# Altium schematic sheet 02 Power.SchDoc
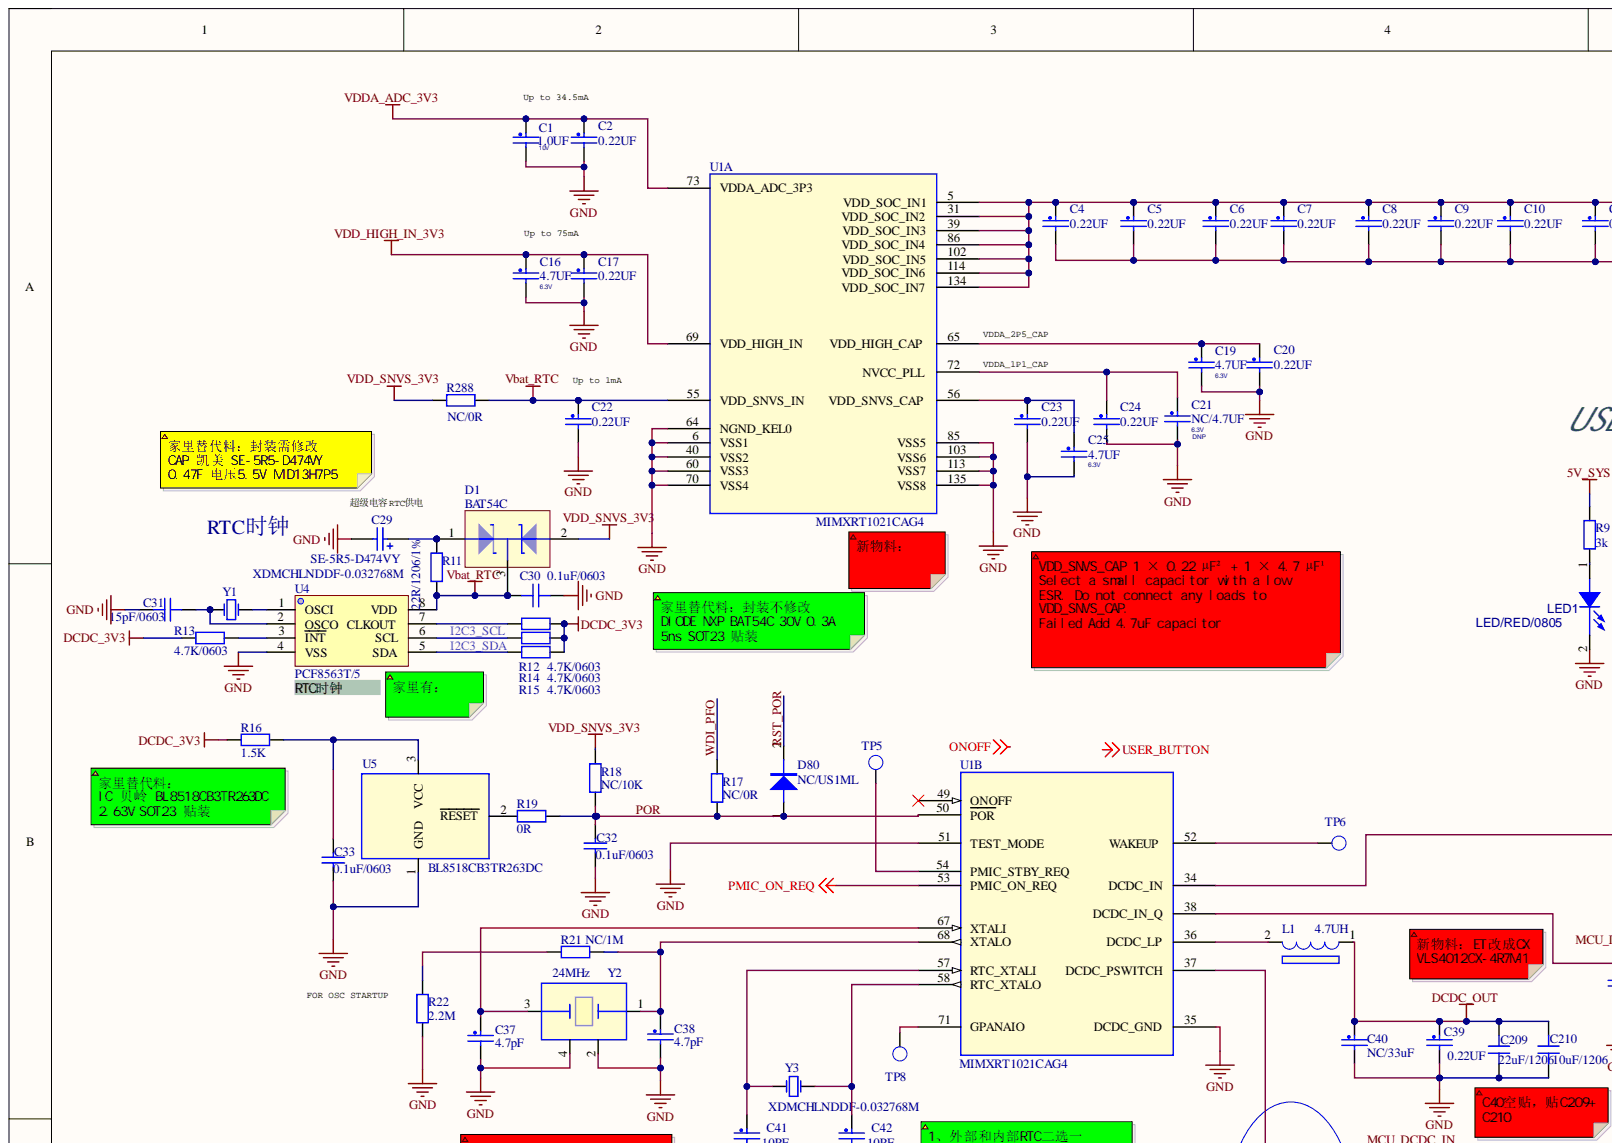
[diagram p0: 02 Power.SchDoc, top left]
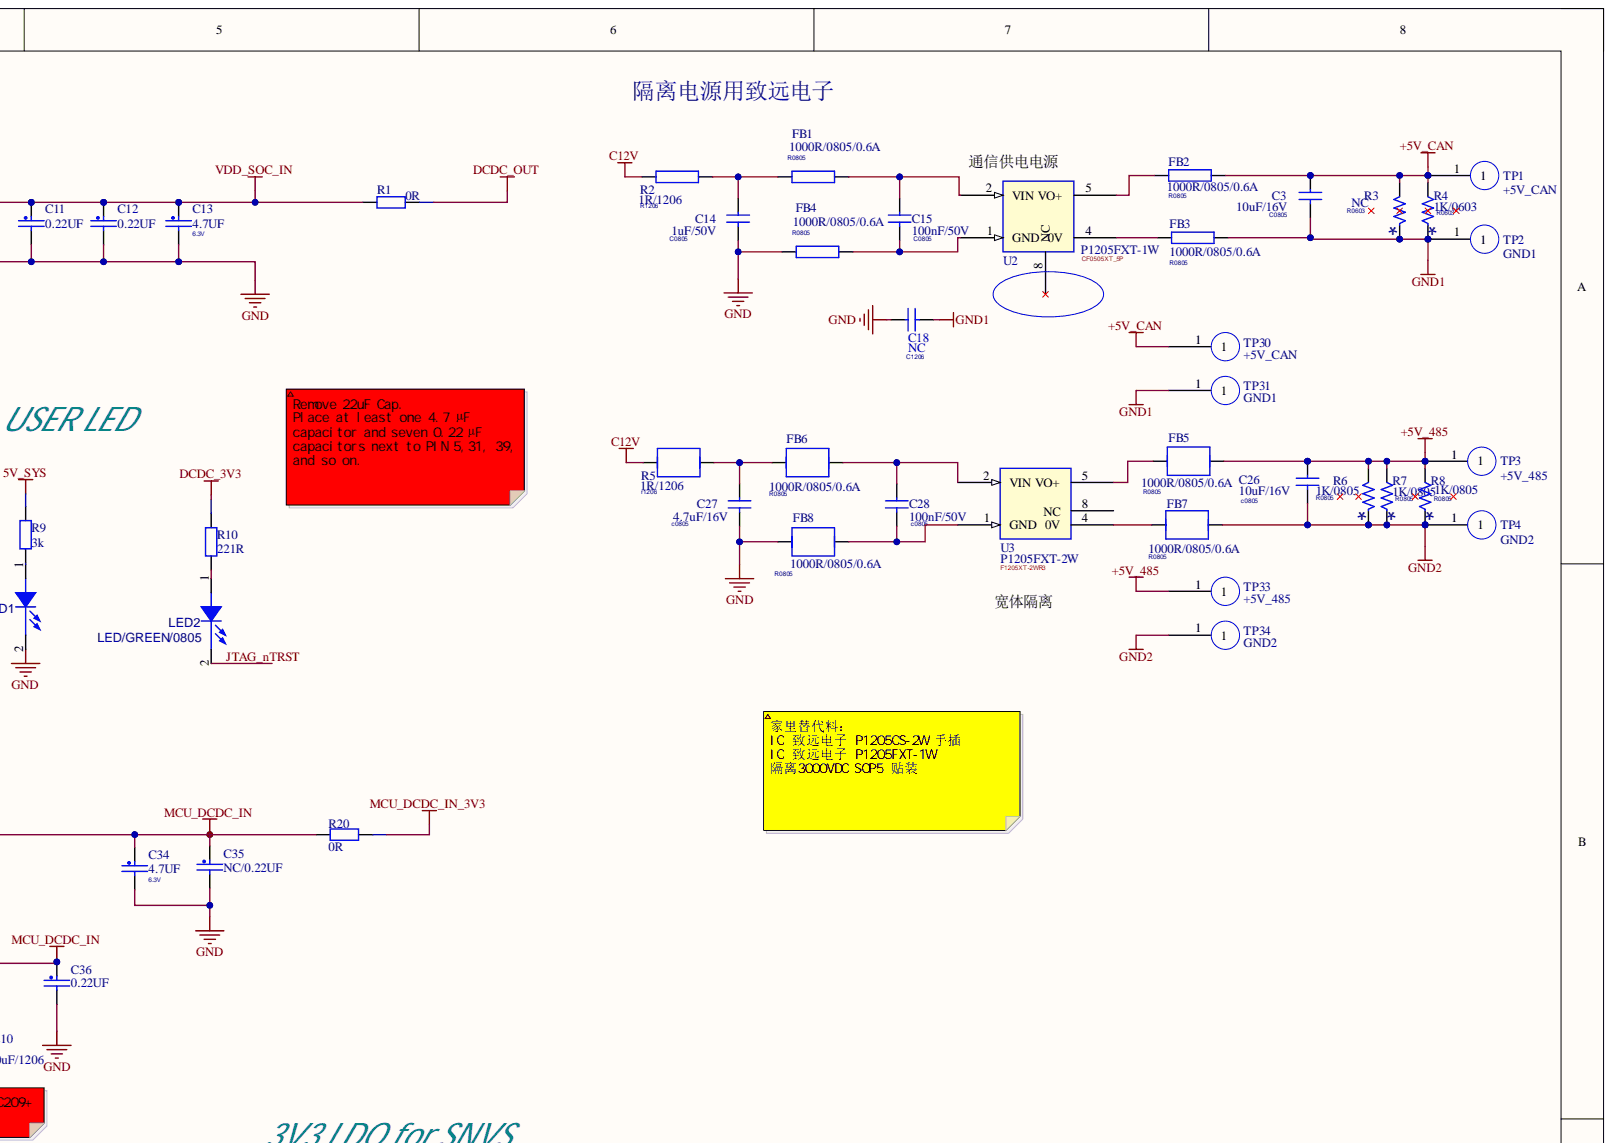
[diagram p1: 02 Power.SchDoc, top right]
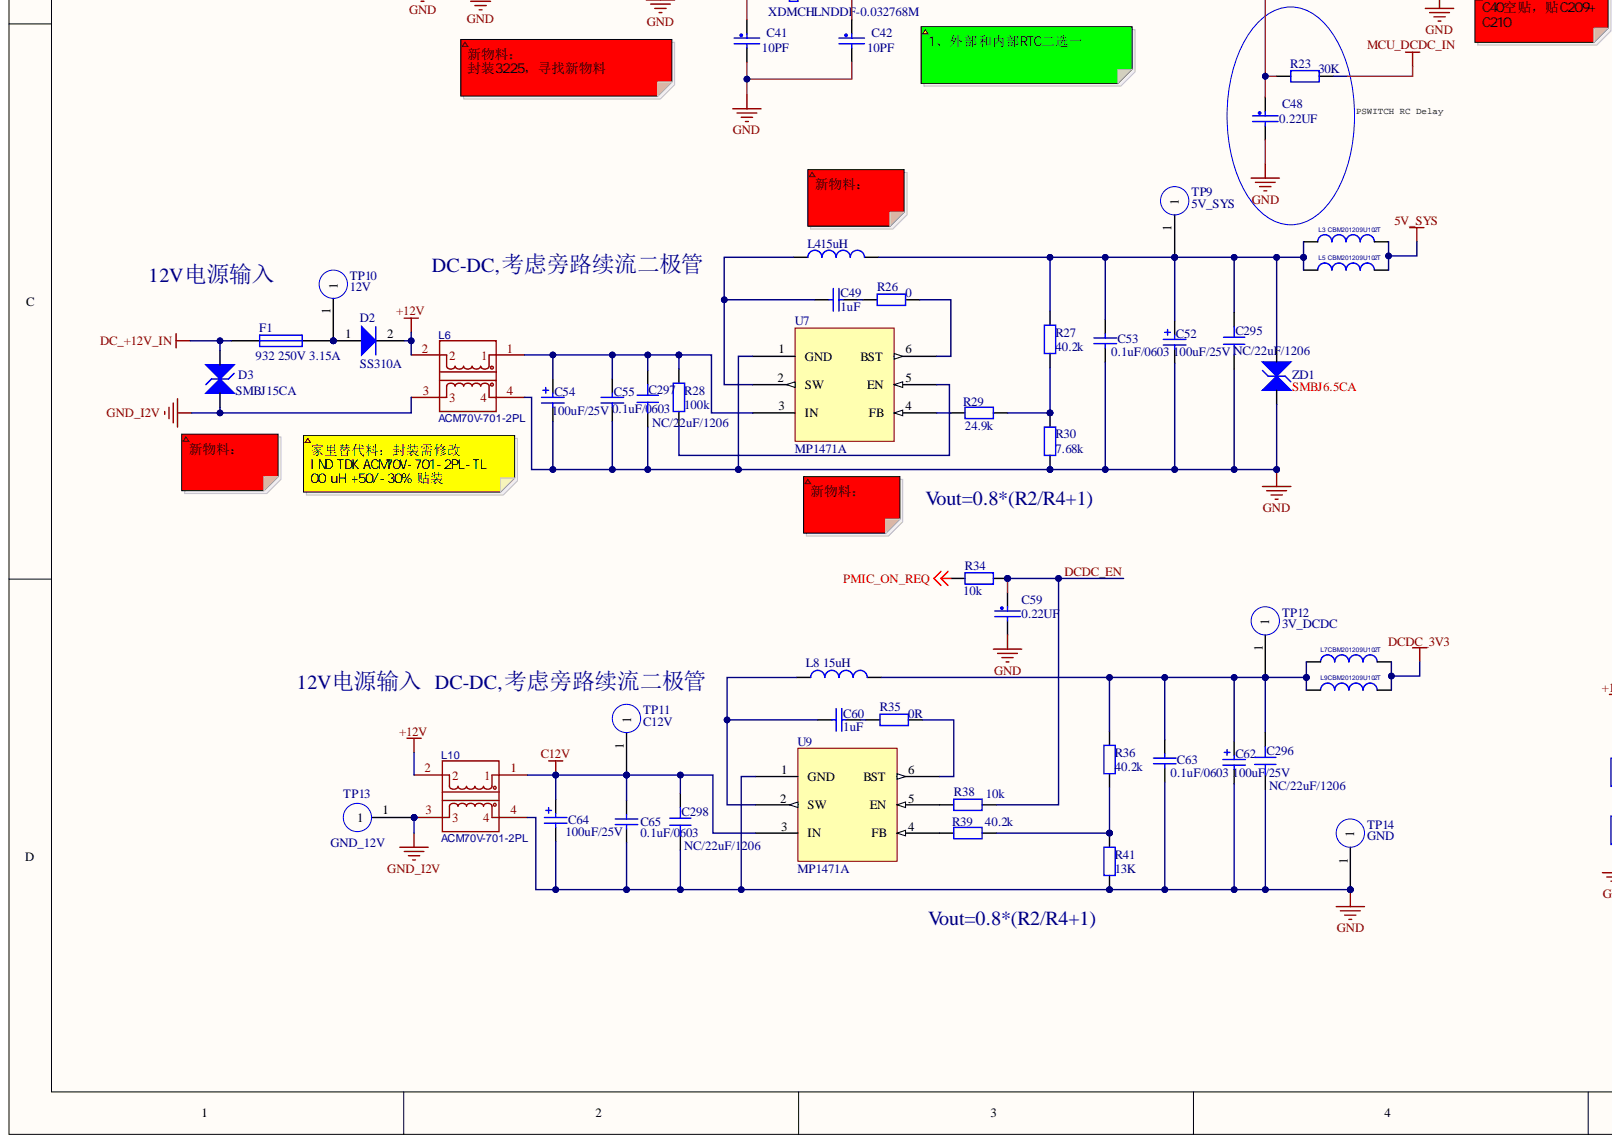
[diagram p2: 02 Power.SchDoc, bottom left]
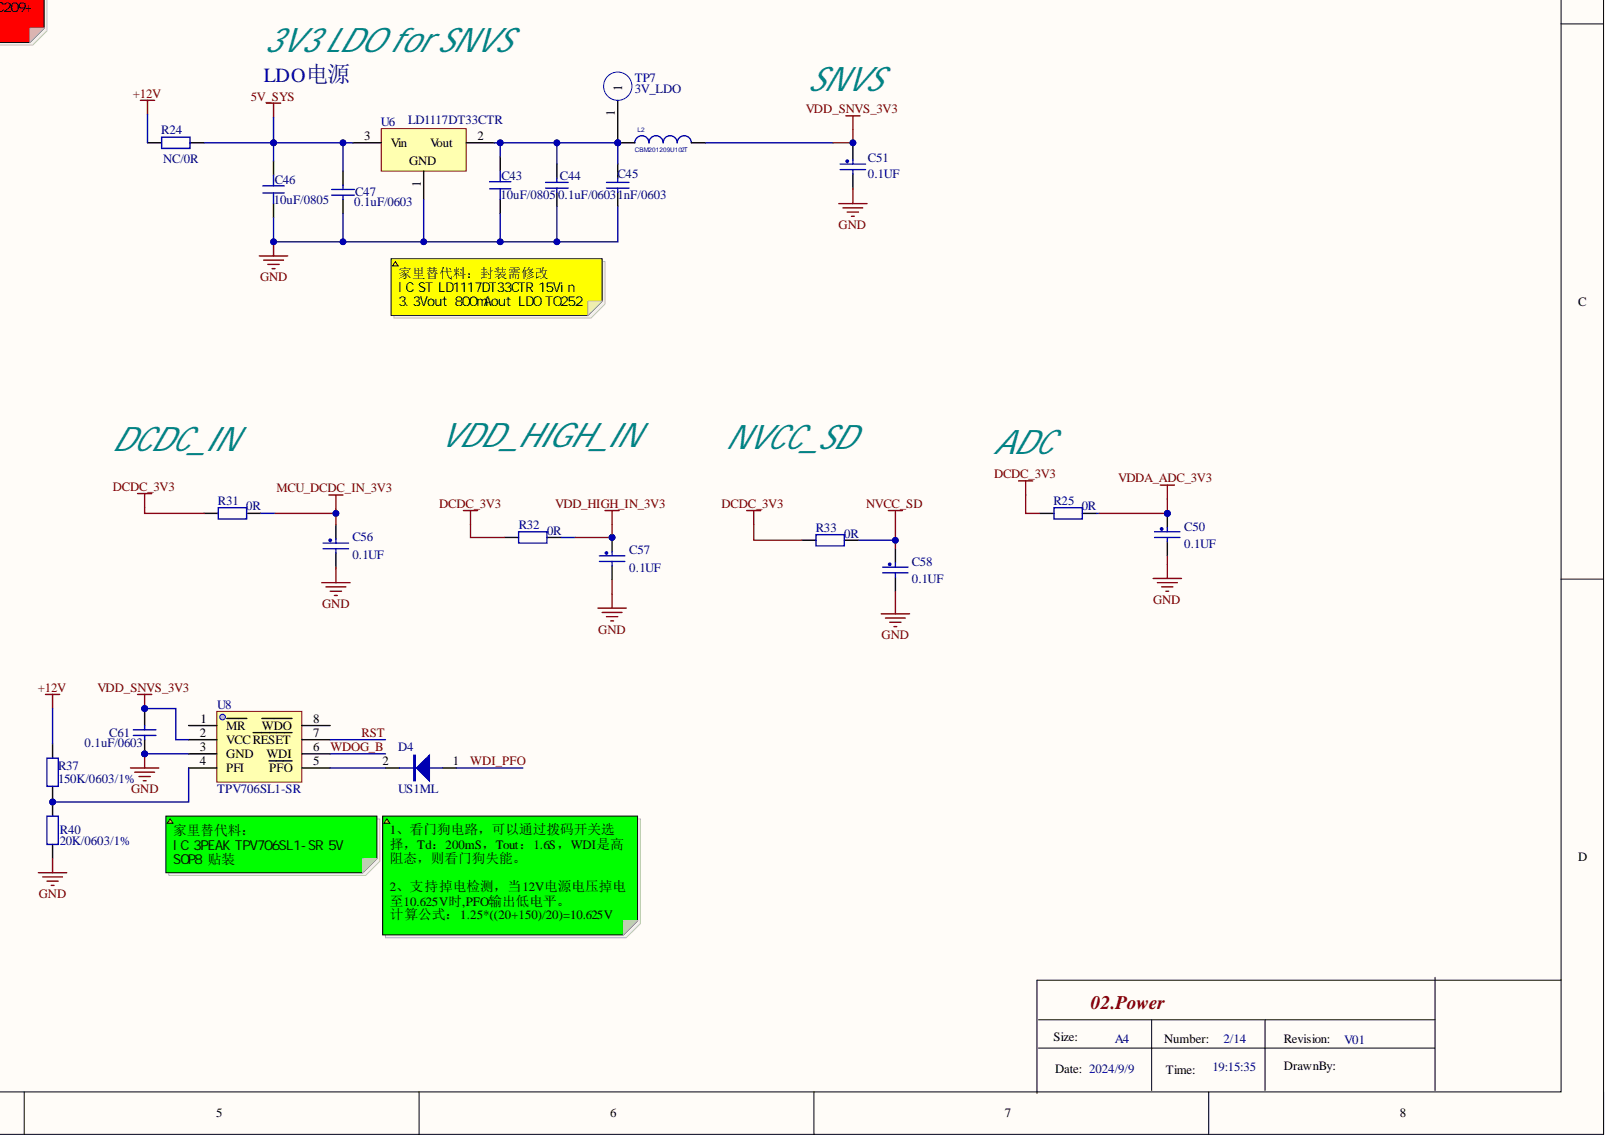
[diagram p3: 02 Power.SchDoc, bottom right]

## Parts
C1  1.0UF  VOLTAGE: 10V  [C0603]
C2  0.22UF  [C0603]
C3  10uF/16V  [C0805]
C4  0.22UF  [C0603]
C5  0.22UF  [C0603]
C6  0.22UF  [C0603]
C7  0.22UF  [C0603]
C8  0.22UF  [C0603]
C9  0.22UF  [C0603]
C10  0.22UF  [C0603]
C11  0.22UF  [C0603]
C12  0.22UF  [C0603]
C13  4.7UF  VOLTAGE: 6.3V  [C0805]
C14  1uF/50V  [C0805]
C15  100nF/50V  [C0805]
C16  4.7UF  VOLTAGE: 6.3V  [C0805]
C17  0.22UF  [C0603]
C18  NC  [C1206]
C19  4.7UF  VOLTAGE: 6.3V  [C0805]
C20  0.22UF  [C0603]
C21  NC/4.7UF  ASSY_OPT: DNP  VOLTAGE: 6.3V  [C0805]
C22  0.22UF  [C0603]
C23  0.22UF  [C0603]
C24  0.22UF  [C0603]
C25  4.7UF  VOLTAGE: 6.3V  [C0805]
C26  10uF/16V  [c0805]
C27  4.7uF/16V  [c0805]
C28  100nF/50V  [c0805]
C29  SE-5R5-D474VY  [HT-5R5-Z474VYL06]
C30  0.1uF/0603  [C0603]
C31  15pF/0603  [C0603]
C32  0.1uF/0603  [C0603]
C33  0.1uF/0603  [C0603]
C34  4.7UF  VOLTAGE: 6.3V  [C0805]
C35  NC/0.22UF  [C0603]
C36  0.22UF  [C0603]
C37  4.7pF  [C0603]
C38  4.7pF  [C0603]
C39  0.22UF  [C0603]
C40  NC/33uF  [C1210]
C41  10PF  [C0603]
C42  10PF  [C0603]
C43  10uF/0805  [C1206]
C44  0.1uF/0603  [C0603]
C45  1nF/0603  [C0603]
C46  10uF/0805  [C1206]
C47  0.1uF/0603  [C0603]
C48  0.22UF  [C0603]
C49  1uF  [C0603]
C50  0.1UF  [C0603]
C51  0.1UF  [C0603]
C52  value 100uF/25V  [CAP D6.3 F2.5]  (hidden comment: 100uF/16V)
C53  0.1uF/0603  [C0603]
C54  value 100uF/25V  [CAP D6.3 F2.5]  (hidden comment: 100uF/16V)
C55  0.1uF/0603  [C0603]
C56  0.1UF  [C0603]
C57  0.1UF  [C0603]
C58  0.1UF  [C0603]
C59  0.22UF  [C0603]
C60  1uF  [C0603]
C61  0.1uF/0603  [C0603]
C62  value 100uF/25V  [CAP D6.3 F2.5]  (hidden comment: 100uF/16V)
C63  0.1uF/0603  [C0603]
C64  value 100uF/25V  [CAP D6.3 F2.5]  (hidden comment: 100uF/16V)
C65  0.1uF/0603  [C0603]
C209  22uF/1206  [C1206]
C210  10uF/1206  [C1206]
C295  NC/22uF/1206  [C1206]
C296  NC/22uF/1206  [C1206]
C297  NC/22uF/1206  [C1206]
C298  NC/22uF/1206  [C1206]
D1  BAT54C  [SOT-23]
D2  SS310A  [SMA]
D3  SMBJ15CA  [SMB]
D4  US1ML  [SOD123]
D80  NC/US1ML  [SOD123]
F1  932 250V 3.15A  [JFC2410-1300TS]
FB1  1000R/0805/0.6A  [R0805]
FB2  1000R/0805/0.6A  [R0805]
FB3  1000R/0805/0.6A  [R0805]
FB4  1000R/0805/0.6A  [R0805]
FB5  1000R/0805/0.6A  [R0805]
FB6  1000R/0805/0.6A  [R0805]
FB7  1000R/0805/0.6A  [R0805]
FB8  1000R/0805/0.6A  [R0805]
L1  4.7UH  [L4.0XW4.0]
L2  CBM201209U102T  [L0805]
L3  CBM201209U102T  [L0805]
L4  15uH  [MS127-150MT]
L5  CBM201209U102T  [L0805]
L6  ACM70V-701-2PL  [SMD5X5X2]
L7  CBM201209U102T  [L0805]
L8  15uH  [MS127-150MT]
L9  CBM201209U102T  [L0805]
L10  ACM70V-701-2PL  [SMD5X5X2]
LED1  LED/RED/0805  [D0805]
LED2  LED/GREEN/0805  [D0805]
R1  0R  [R0603]
R2  1R/1206  [R1206]
R3  NC  [R0603]
R4  1K/0603  [R0603]
R5  1R/1206  [r1206]
R6  1K/0805  [R0805]
R7  1K/0805  [R0805]
R8  1K/0805  [R0805]
R9  3k  [R0603]
R10  221R  [R0603]
R11  22R/1206/1%  [R1206]
R12  4.7K/0603  [R0603]
R13  4.7K/0603  [R0603]
R14  4.7K/0603  [R0603]
R15  4.7K/0603  [R0603]
R16  1.5K  [R0603]
R17  NC/0R  [R0603]
R18  NC/10K  [R0603]
R19  0R  [R0603]
R20  0R  [R0603]
R21  NC/1M  [R0603]
R22  2.2M  [R0603]
R23  30K  [R0603]
R24  NC/0R  [R0603]
R25  0R  [R0603]
R26  0  [R0603]
R27  40.2k  [R0603]
R28  100k  [R0603]
R29  24.9k  [R0603]
R30  7.68k  [R0603]
R31  0R  [R0603]
R32  0R  [R0603]
R33  0R  [R0603]
R34  10k  [R0603]
R35  0R  [R0603]
R36  40.2k  [R0603]
R37  150K/0603/1%  [R0603]
R38  10k  [R0603]
R39  40.2k  [R0603]
R40  20K/0603/1%  [R0603]
R41  13K  [R0603]
R288  NC/0R  [R0603]
TP1  +5V_CAN  [TP12]
TP2  GND1  [TP12]
TP3  +5V_485  [TP12]
TP4  GND2  [TP12]
TP5  TP_35MIL  [TP12]  (value not drawn)
TP6  TP_35MIL  [TP12]  (value not drawn)
TP7  3V_LDO  [TP12]
TP8  TP_35MIL  [TP12]  (value not drawn)
TP9  5V_SYS  [TP12]
TP10  12V  [TP12]
TP11  C12V  [TP12]
TP12  3V_DCDC  [TP12]
TP13  GND_12V  [TP12]
TP14  GND  [TP12]
TP30  +5V_CAN  [TP12]
TP31  GND1  [TP12]
TP33  +5V_485  [TP12]
TP34  GND2  [TP12]
U1  MIMXRT1021CAG4  [LQFP-144_MIMXRT1021CAG4]  (parts A,B)
U2  P1205FXT-1W  [CF0505XT_5P]
U3  P1205FXT-2W  [F1205XT-2WR3]
U4  PCF8563T/5  [SOIC-8_150mil]
U5  BL8518CB3TR263DC  [sot-23]
U6  LD1117DT33CTR  [TO-252]
U7  MP1471A  [SOT-23-6]
U8  TPV706SL1-SR  [SOIC-8_150mil]
U9  MP1471A  [SOT-23-6]
Y1  XDMCHLNDDF-0.032768M  [SMD-3215]
Y2  24MHz  [SMD-3225]
Y3  XDMCHLNDDF-0.032768M  [SMD-3215]
ZD1  SMBJ6.5CA  [SMB]

## Nets
5V_SYS: C3.1 C26.1 C46.1 C47.2 FB2.1 FB5.2 L3.2 L5.2 R3.2 R4.2 R6.2 R7.2 R8.2 R9.2 R24.2 TP1.1 TP3.1 TP30.1 TP33.1 U6.3
+12V: D2.2 L6.2 L10.2 R24.1 R37.2
C12V: C64.1 C65.2 C298.1 L10.1 R2.1 R5.1 TP11.1 U9.3(IN)
DC_+12V_IN: D3.1 F1.1
DCDC_3V3: L7.2 L9.2 R10.2 R12.1 R13.2 R14.1 R15.1 R16.2 R25.1 R31.1 R32.1 R33.1
DCDC_OUT: C39.1 C40.1 C209.1 C210.1 L1.1 R1.2
GND: C1.2 C2.2 C4.2 C5.2 C6.2 C7.2 C8.2 C9.2 C10.2 C11.2 C12.2 C13.2 C14.2 C16.2 C17.2 C18.1 C19.2 C20.2 C21.2 C22.2 C23.2 C24.2 C25.2 C27.2 C29.2 C30.2 C31.2 C32.1 C33.1 C34.2 C35.2 C36.2 C37.2 C38.2 C39.2 C40.2 C41.2 C42.2 C43.2 C44.1 C45.1 C46.2 C47.1 C48.2 C50.2 C51.2 C52.2 C53.1 C54.2 C55.1 C56.2 C57.2 C58.2 C59.2 C61.1 C62.2 C63.1 C64.2 C65.1 C209.2 C210.2 C295.2 C296.2 C297.2 C298.2 FB4.2 FB8.1 L6.4 L10.4 LED1.2 R22.1 R30.2 R40.1 R41.2 TP14.1 U1.6(VSS1) U1.35(DCDC_GND) U1.40(VSS2) U1.51(TEST_MODE) U1.60(VSS3) U1.64(NGND_KEL0) U1.70(VSS4) U1.85(VSS5) U1.103(VSS6) U1.113(VSS7) U1.135(VSS8) U4.4(VSS) U5.1 U6.1 U7.1(GND) U8.3(GND) U9.1(GND) Y2.2 Y2.4 ZD1.1
GND1: C3.2 C18.2 FB3.1 R3.1 R4.1 TP2.1 TP31.1
GND2: C26.2 FB7.2 R6.1 R7.1 R8.1 TP4.1 TP34.1
GND_I2V: D3.2 L6.3 L10.3 TP13.1
MCU_DCDC_IN: C34.1 C35.1 C36.1 R20.1 R23.2 U1.34(DCDC_IN) U1.38(DCDC_IN_Q)
MCU_DCDC_IN_3V3: C56.1 R20.2 R31.2
NVCC_SD: C58.1 R33.2
PMIC_ON_REQ: R34.1 U1.53(PMIC_ON_REQ)
Vbat_RTC: C22.1 C30.1 D1.3 R11.1 R288.2 U1.55(VDD_SNVS_IN) U4.8(VDD)
VDD_HIGH_IN_3V3: C16.1 C17.1 C57.1 R32.2 U1.69(VDD_HIGH_IN)
VDD_SNVS_3V3: C51.1 C61.2 D1.2 L2.2 R18.2 R288.1 U8.2(VCC)
VDD_SOC_IN: C4.1 C5.1 C6.1 C7.1 C8.1 C9.1 C10.1 C11.1 C12.1 C13.1 R1.1 U1.5(VDD_SOC_IN1) U1.31(VDD_SOC_IN2) U1.39(VDD_SOC_IN3) U1.86(VDD_SOC_IN4) U1.102(VDD_SOC_IN5) U1.114(VDD_SOC_IN6) U1.134(VDD_SOC_IN7)
VDDA_ADC_3V3: C1.1 C2.1 C50.1 R25.2 U1.73(VDDA_ADC_3P3)
DCDC_EN: C59.1 R34.2 R38.2
I2C3_SCL: R14.2 U4.6(SCL)
I2C3_SDA: R15.2 U4.5(SDA)
JTAG_nTRST: LED2.2
POR: C32.2 D80.1 R17.1 R18.1 R19.2 U1.50(POR)
RST: U8.7(RESET)
RST_POR: D80.2
WDI_PFO: D4.1 R17.2
WDOG_B: U8.6(WDI)
NetC14_1: C14.1 FB1.2 R2.2
NetC15_1: C15.1 FB1.1 U2.2(VIN)
NetC15_2: C15.2 FB4.1 U2.1(GND)
NetC19_1: C19.1 C20.1 U1.65(VDD_HIGH_CAP)
NetC21_1: C21.1 C24.1 U1.72(NVCC_PLL)
NetC23_1: C23.1 C25.1 U1.56(VDD_SNVS_CAP)
NetC27_1: C27.1 FB6.1 R5.2
NetC28_1: C28.1 FB6.2 U3.2(VIN)
NetC28_2: C28.2 FB8.2 U3.1(GND)
NetC29_1: C29.1 D1.1 R11.2
NetC31_1: C31.1 U4.2(OSCO) Y1.2
NetC33_2: C33.2 R16.1 U5.3
NetC37_1: C37.1 U1.67(XTALI) Y2.3
NetC38_1: C38.1 R21.2 U1.68(XTALO) Y2.1
NetC41_1: C41.1 U1.57(RTC_XTALI) Y3.2
NetC42_1: C42.1 U1.58(RTC_XTALO) Y3.1
NetC43_1: C43.1 C44.2 C45.2 L2.1 TP7.1 U6.2
NetC48_1: C48.1 R23.1 U1.37(DCDC_PSWITCH)
NetC49_1: C49.1 R26.1
NetC49_2: C49.2 L4.1 U7.2(SW)
NetC52_1: C52.1 C53.2 C295.1 L3.1 L4.2 L5.1 R27.1 TP9.1 ZD1.2
NetC54_1: C54.1 C55.2 C297.1 L6.1 R28.1 U7.3(IN)
NetC60_1: C60.1 R35.1
NetC60_2: C60.2 L8.1 U9.2(SW)
NetC62_1: C62.1 C63.2 C296.1 L7.1 L8.2 L9.1 R36.1 TP12.1
NetD2_1: D2.1 F1.2 TP10.1
NetD4_2: D4.2 U8.5(PFO)
NetFB2_2: FB2.2 U2.5(VO+)
NetFB3_2: FB3.2 U2.4(0V)
NetFB5_1: FB5.1 U3.5(VO+)
NetFB7_1: FB7.1 U3.4(0V)
NetL1_2: L1.2 U1.36(DCDC_LP)
NetLED1_1: LED1.1 R9.1
NetLED2_1: LED2.1 R10.1
NetR12_2: R12.2 U4.7(CLKOUT)
NetR13_1: R13.1 U4.3(INT)
NetR19_1: R19.1 U5.2
NetR21_1: R21.1 R22.2
NetR26_2: R26.2 U7.6(BST)
NetR27_2: R27.2 R29.1 R30.1
NetR28_2: R28.2 U7.5(EN)
NetR29_2: R29.2 U7.4(FB)
NetR35_2: R35.2 U9.6(BST)
NetR36_2: R36.2 R39.1 R41.1
NetR37_1: R37.1 R40.2 U8.4(PFI)
NetR38_1: R38.1 U9.5(EN)
NetR39_2: R39.2 U9.4(FB)
NetTP5_1: TP5.1 U1.54(PMIC_STBY_REQ)
NetTP6_1: TP6.1 U1.52(WAKEUP)
NetTP8_1: TP8.1 U1.71(GPANAIO)
NetU4_1: U4.1(OSCI) Y1.1
ONOFF:
USER_BUTTON:

Not connected: U1 49(ONOFF); U2 8(NC); U3 8(NC); U8 1(MR) 8(WDO)

## Library data of the parts
C1 = CAP_NP: CAP CER 1.0UF 10V 10% X5R C0805; AGILE_PN: 150-75772; CAD_APPROVED: Yes; CLASS: DISCRETE; FPLIST: CC0402_25,CC0402_HD,0402_CC; MFG_NAME01: YAGEO AMERICA; MFG_PN01: CC0402KRX5R6BB105; Component Kind: Standard; HEIGHT: 0.6MM; ROHS: Yes
C2 C4-C12 C17 C20 C22-C24 C35 C36 C39 C48 = CAP_NP: CAP CER 0.22UF 6.3V 20% X5R 0603; AGILE_PN: 150-76271; CAD_APPROVED: Yes; CLASS: DISCRETE; FPLIST: CC0402_25,CC0402_HD,0402_CC; MFG_NAME01: MURATA; MFG_PN01: GRM155R60J224ME01; PCB Footprint: 0402_CC; Component Kind: Standard; HEIGHT: 0.55MM; ROHS: Yes; Tolerance: 20%; VOLTAGE: 6.3V
C3 C14 C15 C26-C28 = 30pF-X7R-50V-0805_2_Dup2: Tolerance: 50V,X7R,+/-10%; Component Kind: Standard
  C3 C14 C15: PCB Footprint: C0805
  C26-C28: PCB Footprint: c0805
C13 C16 C19 C21 C25 C34 = CAP_NP: CAP CER 4.7UF 6.3V 20% X5R 0402; AGILE_PN: 150-78463; CAD_APPROVED: Yes; CLASS: DISCRETE; FPLIST: CC0402_25,CC0402_HD,0402_CC; MFG_NAME01: TDK; MFG_PN01: C1005X5R0J475M050BC; PCB Footprint: 0402_CC; Component Kind: Standard; HEIGHT: 0.65MM; ROHS: Yes; Tolerance: 20%; voltage rating: 6.3V
C18 = 30pF-X7R-50V-0805_5: Tolerance: 50V,X7R,+/-10%; Component Kind: Standard; PCB Footprint: C1206
C29 = HT-5R5-Z474VYL06: CAP 凯美 SE-5R5-D474VY 0.47F 电压5.5V M D13H7P5 手插; 品牌: KAMCAP; 焊接类型: THT; Component Kind: Standard; ERP code: ELC.0824; 型号规格: HT-5R5-Z474VYL06; Library Name: Integrated_Library_temporary20181225.IntLib
C30 = CAP: 贴片电容,0.1uF-50V-0603-X7R-10%; ERP code: ELC.0xxx; 品牌: YAGEO; 型号规格: CC0402KRX7R9BB104; 焊接类型: SMT; Component Kind: Standard; Library Name: DH_Device.SchLib
C31 = CAP: 贴片电容 - 15pF±5%-50V-COG; 品牌: YAGEO; 型号规格: CC0603JRNPO9BN150; 焊接类型: SMT; Component Kind: Standard; ERP code: ELC.0392; Library Name: DH_Device.SchLib
C32 C33 C44 C45 C47 C49 C53 C55 C60 C61 C63 C65 = Cap: 无极性电容; 厂: Samsung; Component Kind: Standard; Library Name: 超超的封装库.IntLib
C37 C38 = CAP_NP: CAP CER 4.7PF 50V 0.25PF C0G 0402; AGILE_PN: 150-76335; CAD_APPROVED: Yes; CLASS: DISCRETE; FPLIST: CC0402_25,CC0402_HD,0402_CC; MFG_NAME01: MURATA; MFG_PN01: GRM1555C1H4R7CA01D; PCB Footprint: 0402_CC; Component Kind: Standard; HEIGHT: 0.55MM; ROHS: Yes; Tolerance: 0.25pF; VOLTAGE: 50V
C40 = CAP_NP: CAP CER 33UF 6.3V 20% X5R 1210; AGILE_PN: 150-76796; CAD_APPROVED: Yes; CLASS: DISCRETE; FPLIST: CC1210,1210_CC; MFG_NAME01: MURATA; MFG_PN01: GRM32DR60J336ME19L; PCB Footprint: CC1210; Component Kind: Standard; HEIGHT: 2.2MM; ROHS: Yes; Tolerance: 20%; VOLTAGE: 6.3V
C41 C42 = CAP_NP: CAP CER 10PF 50V 5% C0G 0402; AGILE_PN: 150-75265; CAD_APPROVED: YES; CLASS: DISCRETE; FPLIST: CC0402_25,CC0402_HD,0402_CC; MFG_NAME01: AVX; MFG_PN01: 04025A100JAT2A; PCB Footprint: 0402_CC; Component Kind: Standard; HEIGHT: 0.635MM; ROHS: Yes; Tolerance: 5%; VOLTAGE: 50V
C43 C46 C210 = 22uF_25V_10%_X7R_1210: 片状电容器 - 25V-10uF±10%-X5R; Component Kind: Standard; Library Name: Component Library.IntLib
C50 C51 C56-C58 = CAP_NP: CAP CER 0.1UF 10V 10% X5R 0402; AGILE_PN: 150-75634; CAD_APPROVED: Yes; CLASS: DISCRETE; FPLIST: CC0402_25,CC0402_HD,0402_CC; MFG_NAME01: KEMET; MFG_PN01: C0402C104K8PAC; PCB Footprint: CC0402_25; Component Kind: Standard; HEIGHT: 0.64MM; ROHS: Yes; Tolerance: 10%; VOLTAGE: 10V
C52 C54 C62 C64 = Cap Pol SMT H: 有极性电容 6.3*7; Component Kind: Standard; Published: 8-Jun-2000; Revision: July-2002: Re-released for DXP Platform.; Library Name: EButterflyIntegratedLibrary.IntLib; Publisher: Altium Limited
C59 = CAP_NP: CAP CER 0.22UF 6.3V 20% X5R 0402; AGILE_PN: 150-76271; CAD_APPROVED: Yes; CLASS: DISCRETE; FPLIST: CC0402_25,CC0402_HD,0402_CC; MFG_NAME01: MURATA; MFG_PN01: GRM155R60J224ME01; PCB Footprint: 0402_CC; Component Kind: Standard; HEIGHT: 0.55MM; ROHS: Yes; Tolerance: 20%; VOLTAGE: 6.3V
C209 C295-C298 = 22uF_25V_10%_X7R_1210: 片状电容器 - 25V-22uF±10%-X5R; Component Kind: Standard; Library Name: Component Library.IntLib
D1 = LBAT54CLT1G: 双肖特基二极管; 品牌: LRC; 替换料: BAT54CLT1G/ON; 焊接类型: SMT; Component Kind: Standard; ERP Code: ELC.0410; Library Name: DH_Diode_Standard.IntLib; 型号规格: LBAT54CLT1G
D2 = Diode: DIODE 扬杰 SS310A 100VV 3A SMA; Code_JEDEC: DO-214-AB; Component Kind: Standard; LatestRevisionDate: 17-Jul-2002; LatestRevisionNote: Re-released for DXP Platform.; Library Name: ZKM1V03_1.SCHLIB; PackageDescription: DO-214-AB/SMC; 2 C-Bend Leads; Body 7.9 x 5.9 mm, inc. leads (LxW); PackageReference: SMC; PackageVersion: Sep-1996; Published: 8-Jun-2000; Signal Integrity: Diode; Simulation: DIODE; Publisher: Altium Limited
D3 = Diode TVS: 双向TVS; 厂商: 君耀; 厂商编号: SMBJ20CA; Component Kind: Standard; Library Name: 超超的封装库.IntLib
D4 D80 = SMT_1N4004: DIODE 长晶 US1ML 1000V 1A SOD-123FL 贴装; 品牌: 常州星海; Component Kind: Standard; Library Name: ZKM1V02.SCHLIB
F1 = Fuse 1: FUSE 贝特 932 250V 3.15A 慢断 方形塑封8.3X4.1X7.6P5 机插; 厂商: 力特; 厂商编号: 1206L075/16; Component Kind: Standard; LatestRevisionDate: 17-Jul-2002; LatestRevisionNote: Re-released for DXP Platform.; Library Name: Miscellaneous Devices.IntLib; PackageDescription: Thru-Hole; 2 Leads; PackageReference: PIN-W2/E2.8; Published: 8-Jun-2000; Simulation: FUSE; Publisher: Altium Limited
FB1-FB4 R2 = R_1: Component Kind: Standard
  FB1-FB4: PCB Footprint: R0805
  R2: PCB Footprint: R1206
FB5-FB8 R5 = R_12: Component Kind: Standard
  FB5-FB8: PCB Footprint: R0805
  R5: PCB Footprint: r1206
L1 = IND_FERRITE: IND WW FER 4.7uH@1MHz 1.4A 20% 4012; AGILE_PN: 180-78674; CAD_APPROVED: Yes; CLASS: DISCRETE; MFG_NAME01: TDK; MFG_PN01: VLS4012ET-4R7M; PCB Footprint: ind_vls4012e; Temp_Range: -40C to +105C; Component Kind: Standard; HEIGHT: 1.2MM; PART_TYPE: FERRITE; ROHS: Yes; Tolerance: 20%
L2 L3 L5 L7 L9 = INDUCTOR: IND 风华 CBM201209U102T 1000/100MHz Ω ±25% 贴装; 名称: 贴片磁珠; 品牌名称: TDK; 型号: MPZ2012S102AT000; 物料编码: 200200054; 规格&封装: '1kΩ ±25% @100MHz 0805 1.5A 150mΩ; Component Kind: Standard; 供应商: 颜渊
L4 L8 = Inductor: 电感器; Component Kind: Standard; Library Name: 超超的封装库.IntLib
L6 L10 = 1.4KΩ@100MHz: BOM_Manufacturer: MuRata; BOM_Manufacturer Part: DLW5BTM142SQ2L; nameAlias: Value; BOM_Supplier: LCSC; BOM_Supplier Part: C91596; Component Kind: Standard; Description: 1.4KΩ@100MHz; spicePre: L; spiceSymbolName: DLW5BTM142SQ2L; Library Name: zd3_v1.SCHLIB
LED1 LED2 = 1N6264/TO_0: Component Kind: Standard
R1 R9 R10 R16-R36 R38 R39 R41 R288 = Res: 电阻器; 厂: Samsung; Component Kind: Standard; Library Name: 超超的封装库.IntLib
R3 R4 R6-R8 = RC0402JR-0710KL: RES,10KOhm,+/-5%,1/16W,G,SMD0402; Foxconn Part Number: 610107C00-011-G; Part Number: 61-01000006-03-011; Approval Status: A; CCL: LF; CD: 100; CR6: 1000; Green State: C5; HHPN: 61-01000006-03-011-G; KEYID: 4998; Mfg: VPN; ONLY_DATASHEETNAME: YAGEO-RC0402JR-0710KL-DS.pdf; Relex Category: 3; Relex Subcategory: 2; Remark: Reserved; VPN: 610107C00-VPN-G; Component Kind: Standard; HG: 1000; Mfg Part Number: VPN; Package Type: SMD; Part Type: VPN\ROHS\Resistor\Chip Resistor; PB: 1000; PBB: 1000; PBDE: 1000; RELATED_PARTS: http://ytpdm.efoxconn.com/Windchill/netmarkets/jsp/ext/ytpdm/bom/cis/searchManufacturePart1.jsp?strVPartNumber=610107C00-VPN-G; Sub System: Reserved; Tolerance: +/-5%
  R3 R4: PCB Footprint: R0603
  R6-R8: PCB Footprint: R0805
R11 = 22R/1206/1%: 贴片电阻,22R-1/4W-1206-1%; 品牌: YAGEO; 型号规格: RC1206FR-0722RL; 焊接类型: SMT; Component Kind: Standard; ERP Code: ELC.0616; Library Name: DH_Resistance_Standard.IntLib
R12-R15 = RES: 贴片电阻,4.7K-1/10W-0603-1%; 品牌: YAGEO; 型号规格: RC0603FR-074K7L; 焊接类型: SMT; Component Kind: Standard; ERP code: ELC.0272; Library Name: DH_Device.SchLib
R37 = 150K/0603/1%: 贴片电阻,150K-1/10W-0603-1%; 品牌: YAGEO; 型号规格: RC0603FR-07150KL; 焊接类型: SMT; Component Kind: Standard; ERP code: ELC.0289; Library Name: DH_Resistance_Standard.SchLib
R40 = 20K/0603/1%: 贴片电阻,20K-1/10W-0603-1%; 品牌: YAGEO; 型号规格: RC0603FR-0720KL; 焊接类型: SMT; Component Kind: Standard; ERP code: ELC.0290; Library Name: DH_Resistance_Standard.SchLib
TP1-TP4 TP7 TP9-TP14 TP30 TP31 TP33 TP34 = PAD: Component Kind: Standard; PCB Footprint: TP12
TP5 TP6 TP8 = T POINT R: TEST POINT PAD .035 SMT, no part to order; AGILE_PN: 510-75618; CAD_APPROVED: Yes; CLASS: IC; PCB Footprint: testpoint_35mil; Component Kind: Standard; HEIGHT: 0.1MM; ROHS: Yes
U1 = IMXRT1020_LQFP144P: IC CPU ARM Cortex-M7 500MHZ 1.3V LQFP144; AGILE_PN: 330-77054; CAD_APPROVED: Yes; MFG_NAME01: NXP SEMICONDUCTORS; MFG_PN01: MIMXRT1021DAG5A; PCB Footprint: LQFP144_0P5_20X20MM; SPLIT_INST: TRUE; SWAP_INFO: (S1+S2+S3+S4+S5+S6); CLASS: IC; Component Kind: Standard; HEIGHT: 1.6 MM; ROHS: Yes
U2 = DS12C887_11: P1205FXT-1W，贴片，致远; Component Kind: Standard; PCB Footprint: CF0505XT_5P
U3 = DS12C887_10_Dup1: P1205FXT-2W.致远电子，贴片; Component Kind: Standard; PCB Footprint: F1205XT-2WR3
U4 = PCF8563T/5: RTC芯片-I2C接口,工作电压：1.0V-5.5V; 品牌: NXP; 焊接类型: SMT; Component Kind: Standard; ERP code: ELC.0114; Library Name: DH_Device.SchLib; 型号规格: PCF8563T/5
U5 = SGM803_SOT23-3P: IC VOLT MONITOR 2.63V RST VTH 1-5.5V AUTO SOT-23; AGILE_PN: 315-80553; CAD_APPROVED: Yes; MFG_NAME01: Union Semiconductor, Inc; MFG_PN01: UM803RS; CLASS: IC; Component Kind: Standard; HEIGHT: 1.25MM; PCB Footprint: sot-23; ROHS: Yes
U6 = SOT-223 AMS1117-3.3: IC ST LD1117DT33CTR 15Vin 3.3Vout 800mAout LDO TO252; Component Kind: Standard; ComponentLink1Description: 供应商链接; ComponentLink1URL: http://www.szlcsc.com/product/details_6652.html; Library Name: ZKM1V02.SCHLIB; Package: SOT-223; Supplier: LC; SuppliersPartNumber: C6186
U7 U9 = MP1417: Component Kind: Standard; Library Name: M26_SCH_140527.Lib
U8 = MAX706SESA+T: IC 3PEAK TPV706SL1-SR 5V SOP8 贴装; 品牌: Maxim; 焊接类型: SMT; Component Kind: Standard; ERP Code: ELC.0574; Library Name: DH_Device_V1.SchLib; 型号规格: MAX706SESA+T
Y1 Y3 = XTAL: 晶振-32.768kHz-2pin-20ppm-12.5pf,-40℃~+85℃，3.2mm*1.5mm，泰艺; 品牌: 泰艺; 焊接类型: SMT; Component Kind: Standard; ERP code: ELC.0170; Library Name: DH_Device.SchLib; 型号规格: XDMCHLNDDF-0.032768M
Y2 = XTAL_13: X1E0000210129，24MHZ-TSX-3225-爱普生; AGILE_PN: 230-78216; CAD_APPROVED: Yes; CLASS: IC; MFG_NAME01: MURATA; MFG_PN01: XRCGB24M000F2P29R0; PCB Footprint: xtl4_2p1x1p7mm_sm; Temp_Range: -30C to 85C; Component Kind: Standard; HEIGHT: 0.7MM; ROHS: Yes
ZD1 = Diode TVS dubole: 双向TVS; Component Kind: Standard
